# Altium schematic sheet module_esp_schematic.SchDoc(module_esp_schematic)
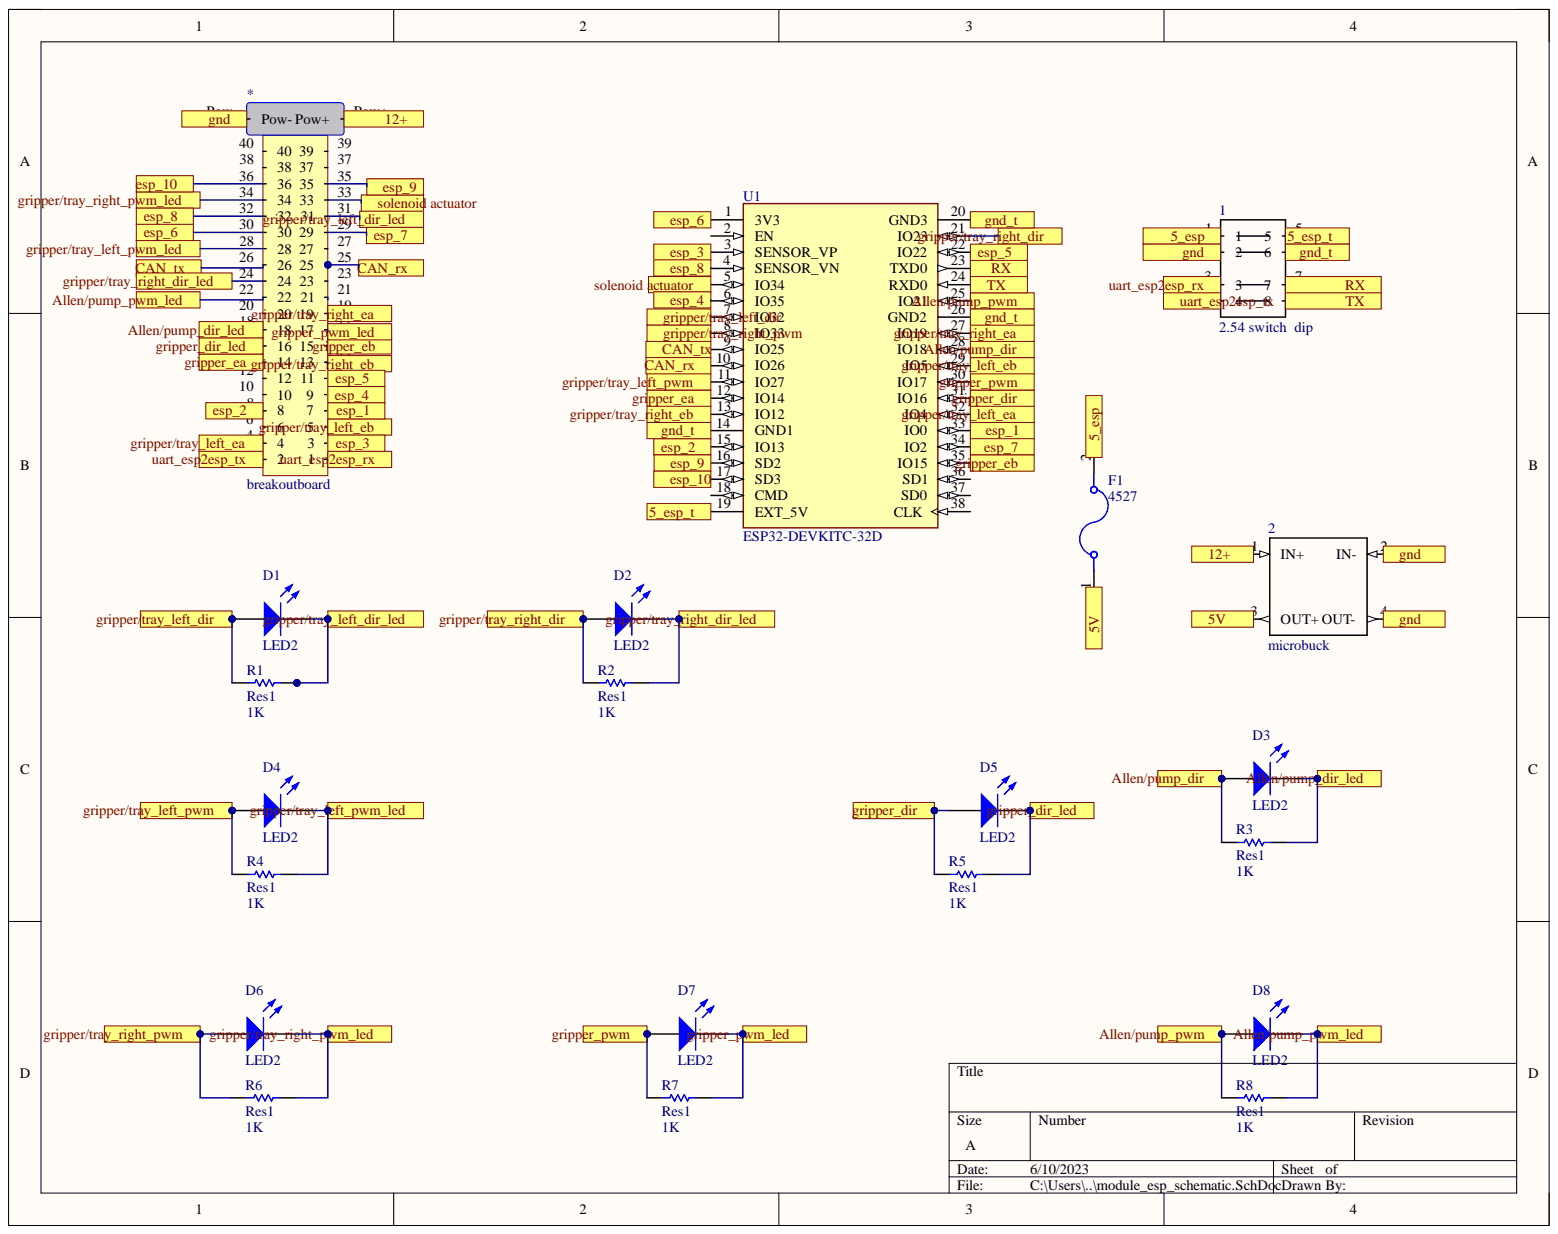
[diagram p0: module_esp_schematic.SchDoc]

## Parts
1  2.54 switch  dip  [2.54mm Pitch 4 Way DIP Slide Switch]
2  microbuck  [Micro buck]
*  breakoutboard  [breakoutboard connections]
D1  LED2  [3.2X1.6X1.1]
D2  LED2  [3.2X1.6X1.1]
D3  LED2  [3.2X1.6X1.1]
D4  LED2  [3.2X1.6X1.1]
D5  LED2  [3.2X1.6X1.1]
D6  LED2  [3.2X1.6X1.1]
D7  LED2  [3.2X1.6X1.1]
D8  LED2  [3.2X1.6X1.1]
F1  4527  [FUSE_4527]
R1  Res1  value 1K  [AXIAL-0.3]
R2  Res1  value 1K  [AXIAL-0.3]
R3  Res1  value 1K  [AXIAL-0.3]
R4  Res1  value 1K  [AXIAL-0.3]
R5  Res1  value 1K  [AXIAL-0.3]
R6  Res1  value 1K  [AXIAL-0.3]
R7  Res1  value 1K  [AXIAL-0.3]
R8  Res1  value 1K  [AXIAL-0.3]
U1  ESP32-DEVKITC-32D  [MODULE_ESP32-DEVKITC-32D]

## Ports
5_esp, 5_esp_t, 5V, 12+, Allen/pump_dir, Allen/pump_dir_led, Allen/pump_pwm, Allen/pump_pwm_led, CAN_rx, CAN_tx, esp_1, esp_2, esp_3, esp_4, esp_5, esp_6, esp_7, esp_8, esp_9, esp_10, gnd, gnd_t, gripper/tray_left_dir, gripper/tray_left_dir_led, gripper/tray_left_ea, gripper/tray_left_eb, gripper/tray_left_pwm, gripper/tray_left_pwm_led, gripper/tray_right_dir, gripper/tray_right_dir_led, gripper/tray_right_ea, gripper/tray_right_eb, gripper/tray_right_pwm, gripper/tray_right_pwm_led, gripper_dir, gripper_dir_led, gripper_ea, gripper_eb, gripper_pwm, gripper_pwm_led, RX, solenoid actuator, TX, uart_esp2esp_rx, uart_esp2esp_tx

## Nets
5_esp: 1.1 F1.2 ; port 5_esp
5_esp_t: 1.5 U1.19(EXT_5V) ; port 5_esp_t
5V: 2.3(OUT+) F1.1 ; port 5V
12+: 2.1(IN+) *.Pow+ ; port 12+
Allen/pump_dir: D3.1 R3.1 U1.28(IO18) ; port Allen/pump_dir
Allen/pump_dir_led: *.18 D3.2 R3.2 ; port Allen/pump_dir_led
Allen/pump_pwm: D8.1 R8.1 U1.25(IO21) ; port Allen/pump_pwm
Allen/pump_pwm_led: *.22 D8.2 R8.2 ; port Allen/pump_pwm_led
CAN_rx: *.25 U1.10(IO26) ; port CAN_rx
CAN_tx: *.26 U1.9(IO25) ; port CAN_tx
esp_1: *.7 U1.33(IO0) ; port esp_1
esp_2: *.8 U1.15(IO13) ; port esp_2
esp_3: *.3 U1.3(SENSOR_VP) ; port esp_3
esp_4: *.9 U1.6(IO35) ; port esp_4
esp_5: *.11 U1.22(IO22) ; port esp_5
esp_6: *.30 U1.1(3V3) ; port esp_6
esp_7: *.29 U1.34(IO2) ; port esp_7
esp_8: *.32 U1.4(SENSOR_VN) ; port esp_8
esp_9: *.35 U1.16(SD2) ; port esp_9
esp_10: *.36 U1.17(SD3) ; port esp_10
gnd: 1.2 2.2(IN-) 2.4(OUT-) *.Pow- ; port gnd
gnd_t: 1.6 U1.14(GND1) U1.20(GND3) U1.26(GND2) ; port gnd_t
gripper/tray_left_dir: D1.1 R1.1 U1.7(IO32) ; port gripper/tray_left_dir
gripper/tray_left_dir_led: *.31 D1.2 R1.2 ; port gripper/tray_left_dir_led
gripper/tray_left_ea: *.4 U1.32(IO4) ; port gripper/tray_left_ea
gripper/tray_left_eb: *.5 U1.29(IO5) ; port gripper/tray_left_eb
gripper/tray_left_pwm: D4.1 R4.1 U1.11(IO27) ; port gripper/tray_left_pwm
gripper/tray_left_pwm_led: *.28 D4.2 R4.2 ; port gripper/tray_left_pwm_led
gripper/tray_right_dir: D2.1 R2.1 U1.21(IO23) ; port gripper/tray_right_dir
gripper/tray_right_dir_led: *.24 D2.2 R2.2 ; port gripper/tray_right_dir_led
gripper/tray_right_ea: *.19 U1.27(IO19) ; port gripper/tray_right_ea
gripper/tray_right_eb: *.13 U1.13(IO12) ; port gripper/tray_right_eb
gripper/tray_right_pwm: D6.1 R6.1 U1.8(IO33) ; port gripper/tray_right_pwm
gripper/tray_right_pwm_led: *.34 D6.2 R6.2 ; port gripper/tray_right_pwm_led
gripper_dir: D5.1 R5.1 U1.31(IO16) ; port gripper_dir
gripper_dir_led: *.16 D5.2 R5.2 ; port gripper_dir_led
gripper_ea: *.14 U1.12(IO14) ; port gripper_ea
gripper_eb: *.15 U1.35(IO15) ; port gripper_eb
gripper_pwm: D7.1 R7.1 U1.30(IO17) ; port gripper_pwm
gripper_pwm_led: *.17 D7.2 R7.2 ; port gripper_pwm_led
RX: 1.7 U1.23(TXD0) ; port RX
solenoid actuator: *.33 U1.5(IO34) ; port solenoid actuator
TX: 1.8 U1.24(RXD0) ; port TX
uart_esp2esp_rx: 1.3 *.1 ; port uart_esp2esp_rx
uart_esp2esp_tx: 1.4 *.2 ; port uart_esp2esp_tx

Not connected: * 6 10 12 20 21 23 27 37 38 39 40; U1 2(EN) 18(CMD) 36(SD1) 37(SD0) 38(CLK)

## Library data of the parts
D1-D8 = LED2: Typical RED, GREEN, YELLOW, AMBER GaAs LED; Component Kind: Standard; LatestRevisionDate: 17-Jul-2002; LatestRevisionNote: Re-released for DXP Platform.; Library Name: Miscellaneous Devices.IntLib; PackageDescription: SM LED; 2 Flat Leads; PackageReference: 3.2X1.6X1.1; PackageVersion: Feb-2002; Published: 8-Jun-2000; Simulation: LED2; Publisher: Altium Limited
F1 = 4527: Fuseholder; 5 A; 250 V; Nylon; Base only; Brass, Tin Plate (Contact); Availability: Unavailable; Component Kind: Standard; Library Name: 4527.IntLib; MP: 4527; Package: None; Price: None
R1-R8 = Res1: Resistor; Component Kind: Standard; LatestRevisionDate: 17-Jul-2002; LatestRevisionNote: Re-released for DXP Platform.; Library Name: Miscellaneous Devices.IntLib; PackageDescription: Axial Device, Thru-Hole; 2 Leads; 0.3 in Pin Spacing; PackageReference: AXIAL-0.3; Published: 8-Jun-2000; Signal Integrity: Res; Simulation: RESISTOR; Publisher: Altium Limited
U1 = ESP32-DEVKITC-32D: Eval Board For Esp-Wroom-32; Availability: Unavailable; Component Kind: Standard; Library Name: ESP32-DEVKITC-32D.IntLib; MP: ESP32-DEVKITC-32D; Package: None; Price: None
1 = 2.54 switch  dip: Component Kind: Standard; Library Name: Schlib1.SchLib
2 = microbuck: Component Kind: Standard; Library Name: Schlib2.SchLib
* = breakoutboard: Component Kind: Standard; Library Name: Schlib1.SchLib
